# Altium schematic sheet DASH.SchDoc
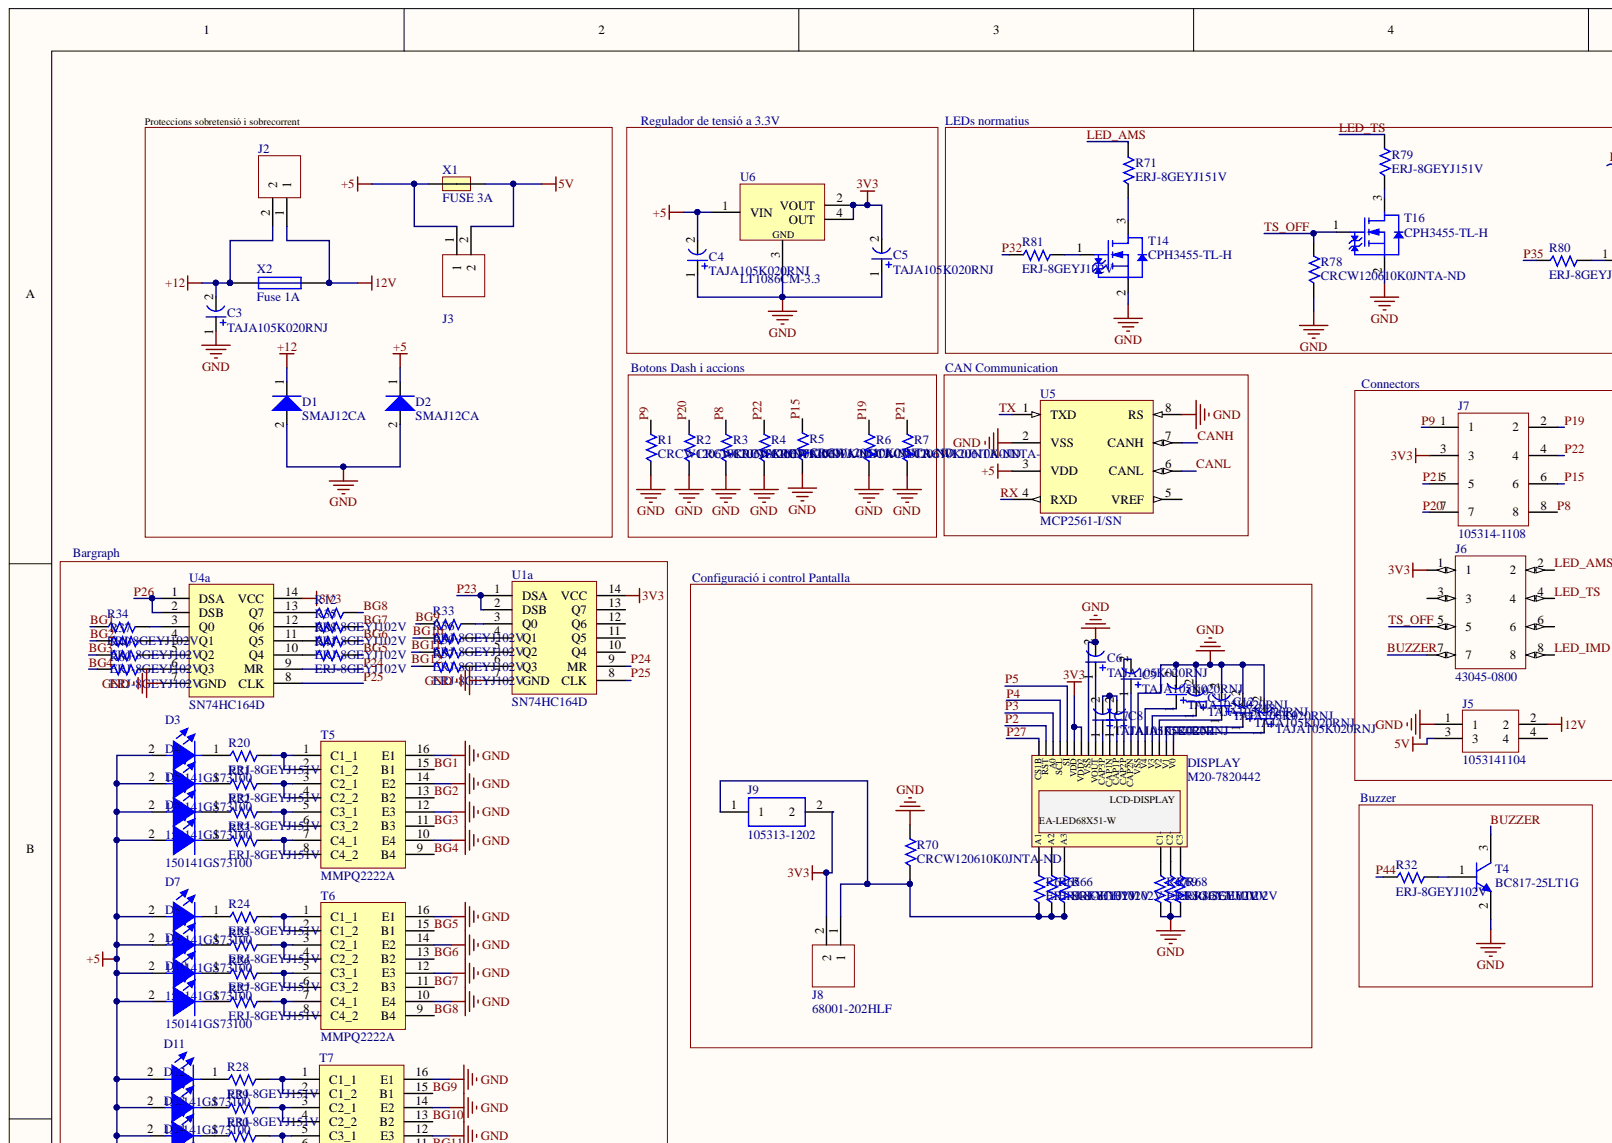
[diagram p0: DASH.SchDoc, top left]
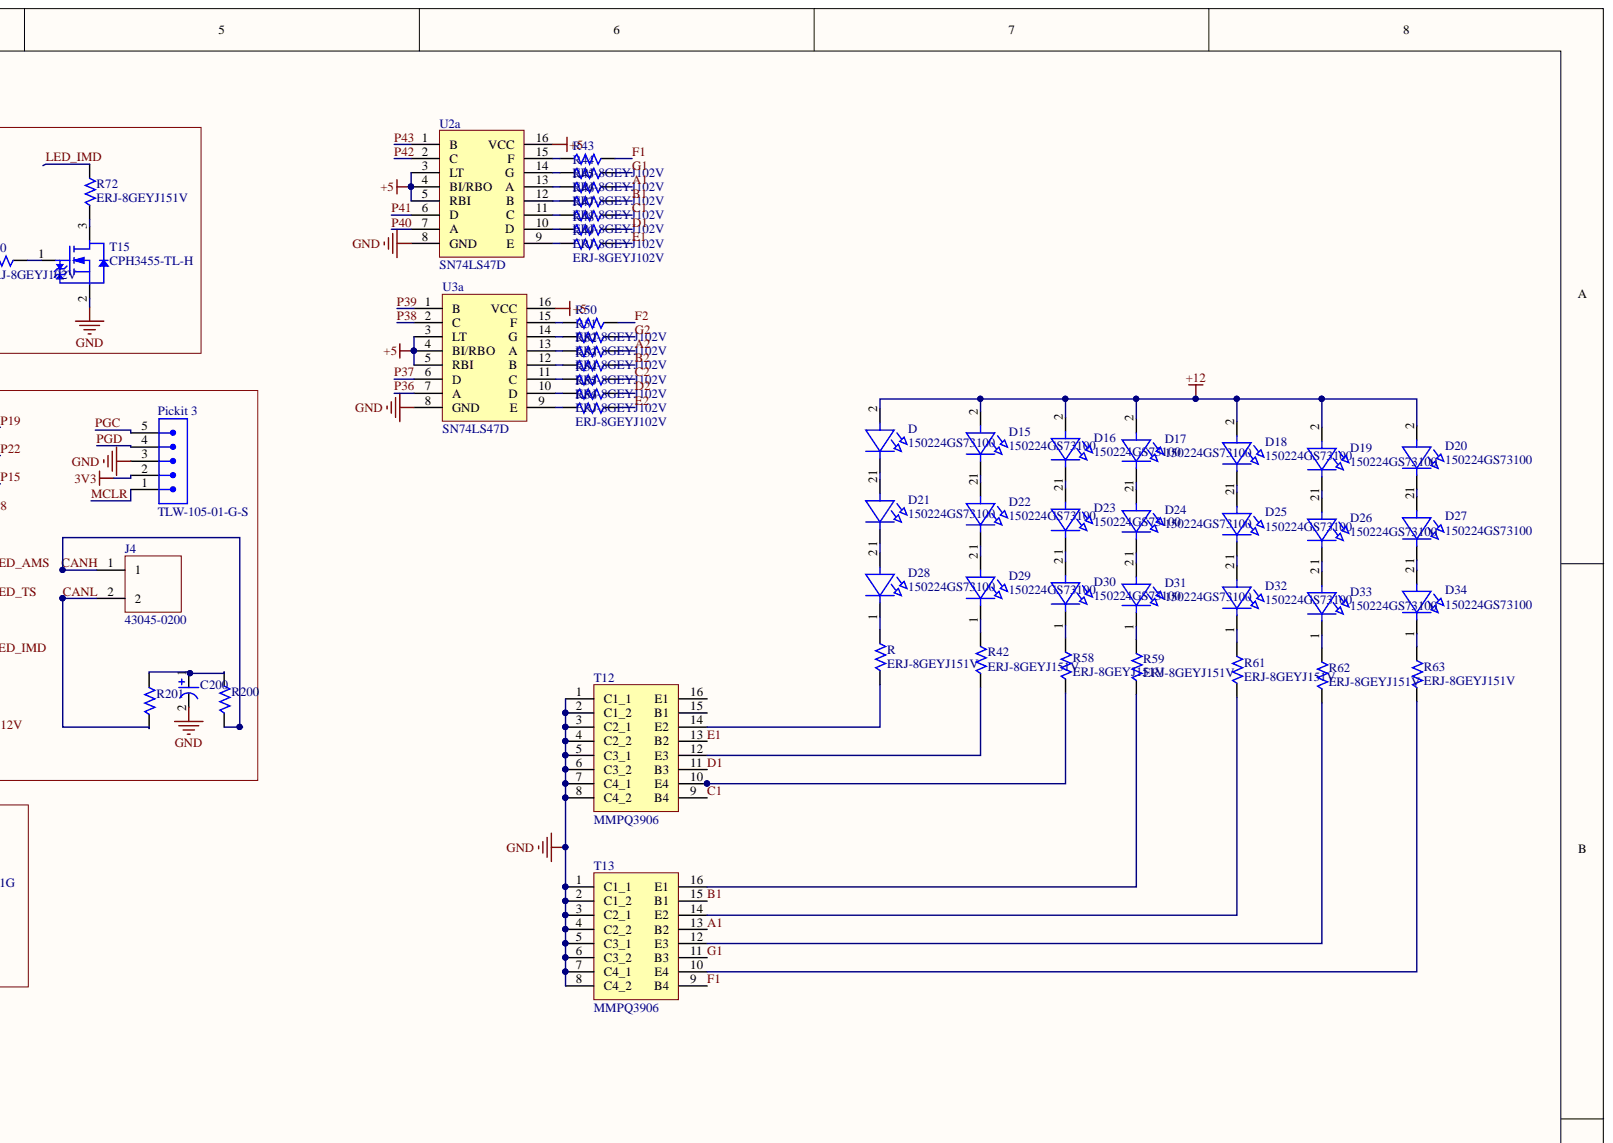
[diagram p1: DASH.SchDoc, top right]
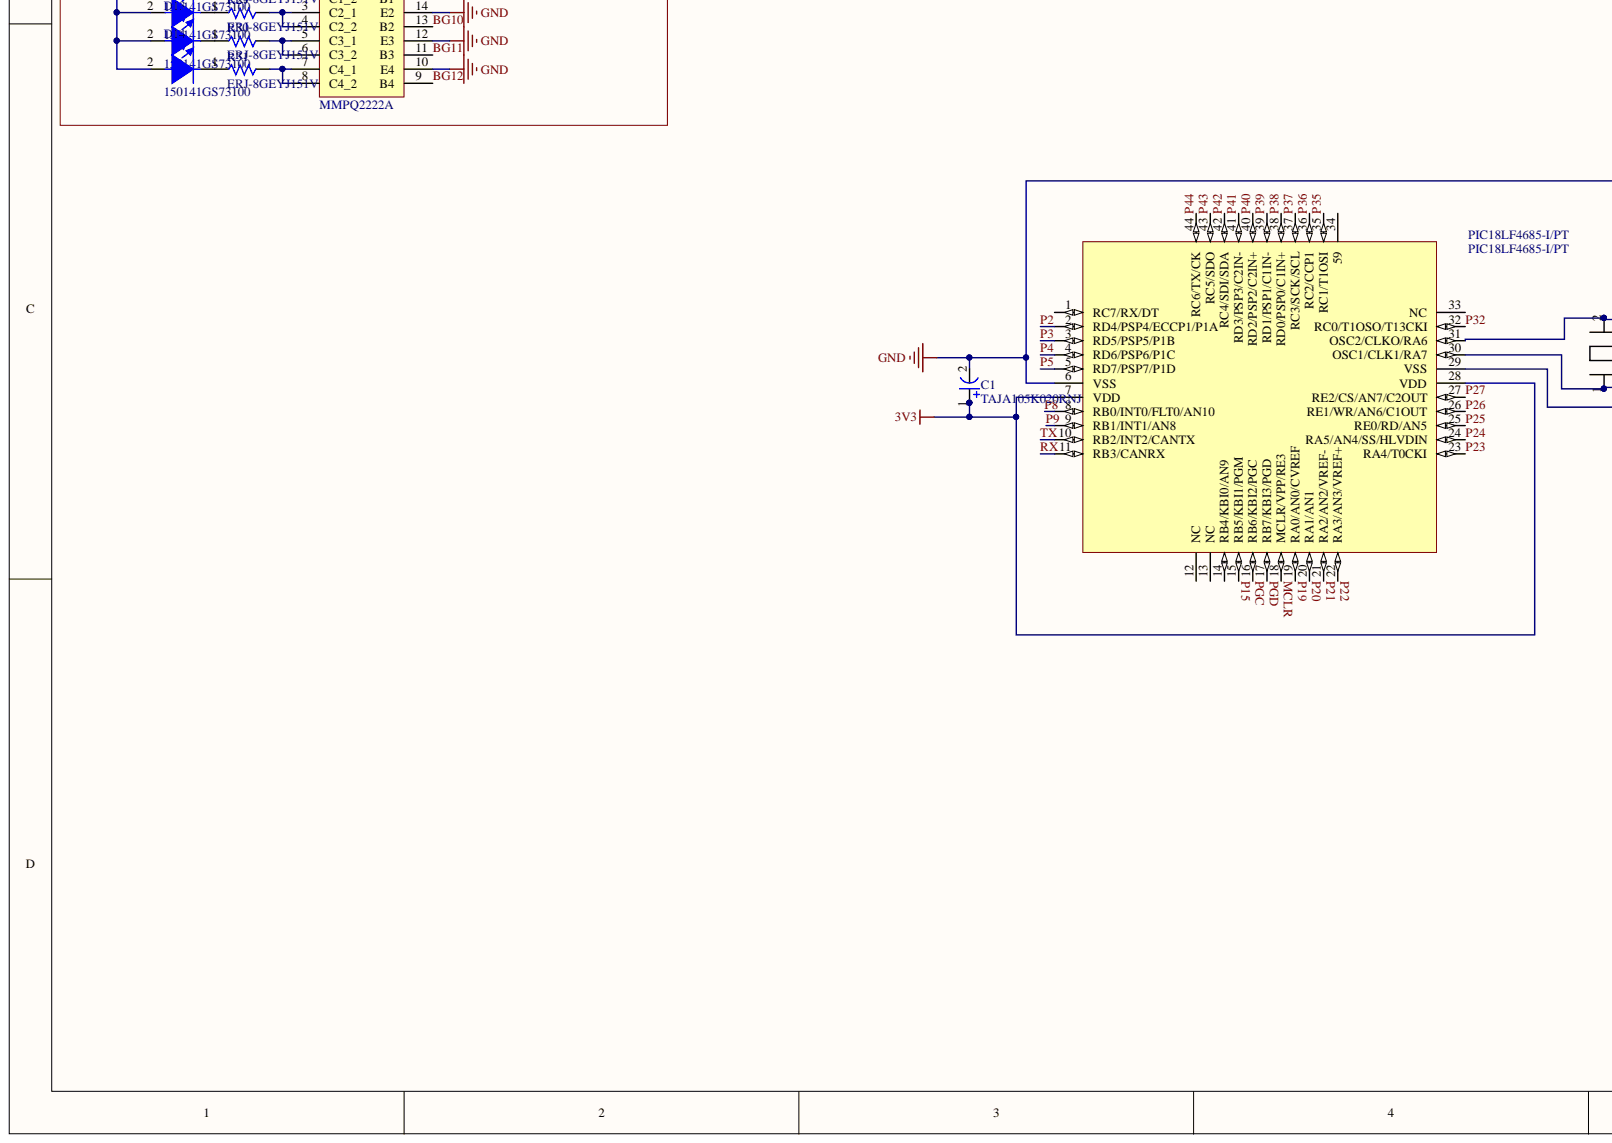
[diagram p2: DASH.SchDoc, bottom left]
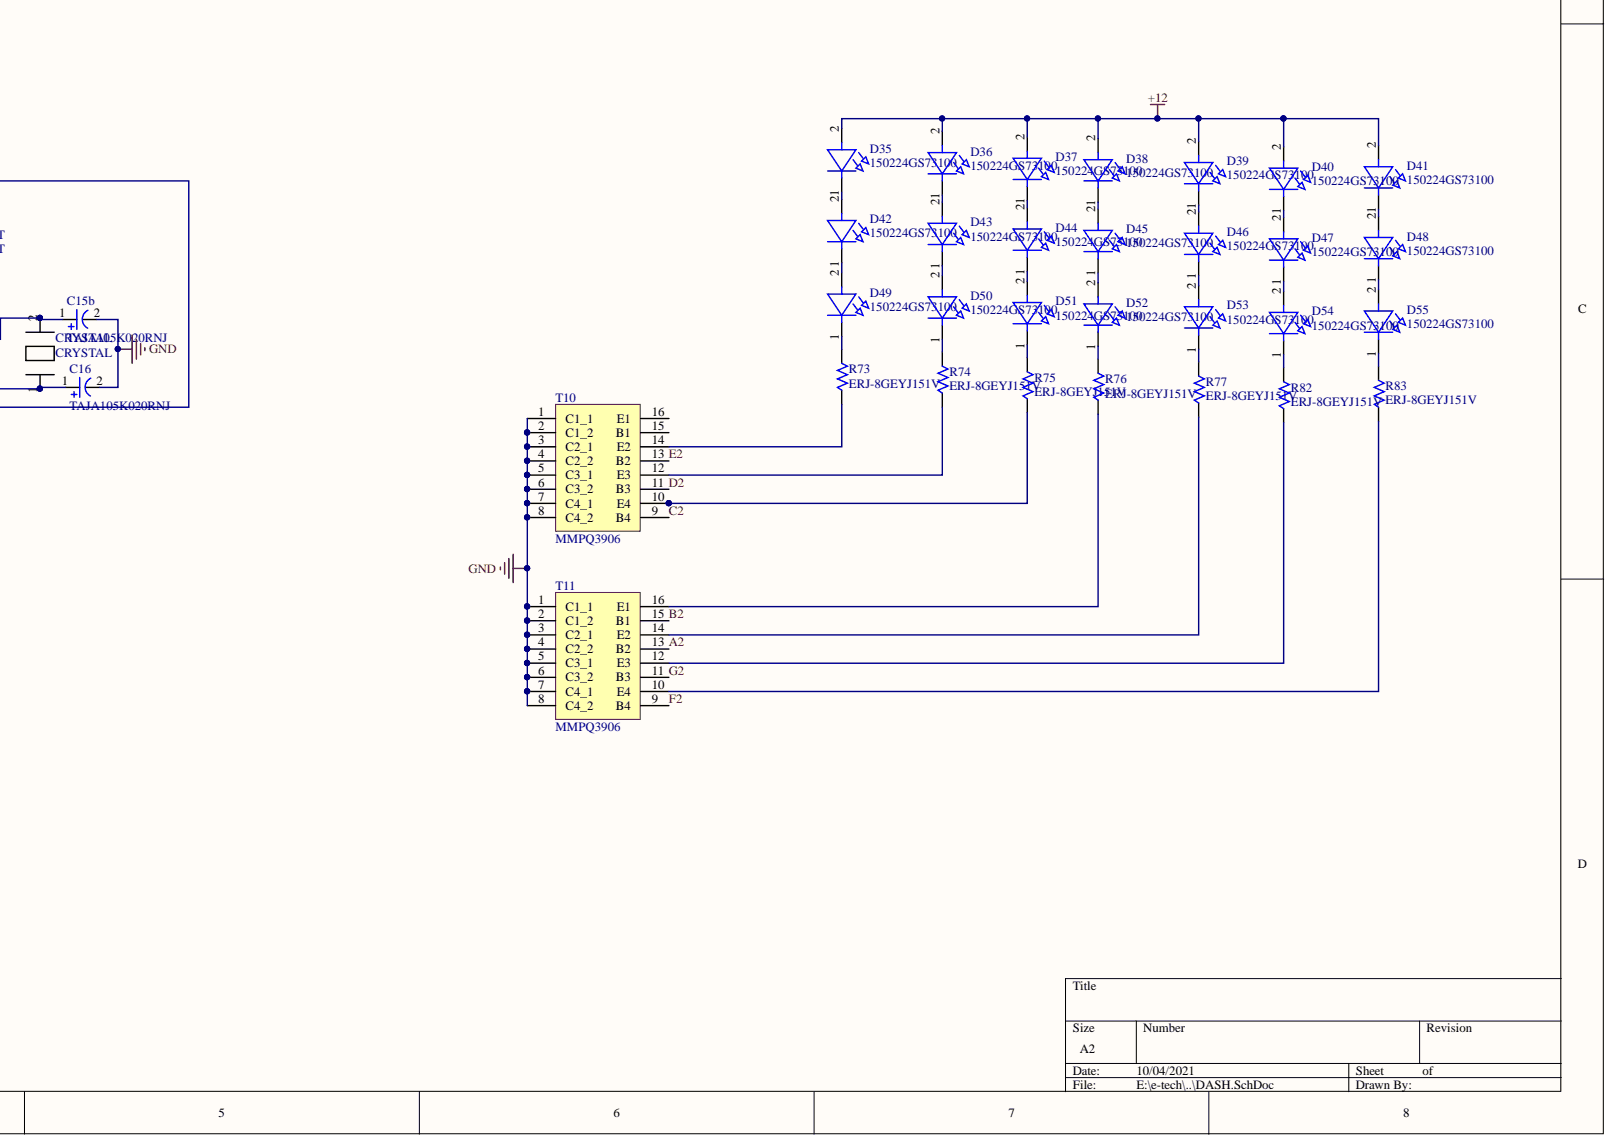
[diagram p3: DASH.SchDoc, bottom right]

## Parts
C1  TAJA105K020RNJ  [Chip2PinSM127P600-8N(condensador)]
C3  TAJA105K020RNJ  [Chip2PinSM127P600-8N(condensador)]
C4  TAJA105K020RNJ  [Chip2PinSM127P600-8N(condensador)]
C5  TAJA105K020RNJ  [Chip2PinSM127P600-8N(condensador)]
C6  TAJA105K020RNJ  [Chip2PinSM127P600-8N(condensador)]
C7  TAJA105K020RNJ  [Chip2PinSM127P600-8N(condensador)]
C8  TAJA105K020RNJ  [Chip2PinSM127P600-8N(condensador)]
C9  TAJA105K020RNJ  [Chip2PinSM127P600-8N(condensador)]
C10  TAJA105K020RNJ  [Chip2PinSM127P600-8N(condensador)]
C11  TAJA105K020RNJ  [Chip2PinSM127P600-8N(condensador)]
C12  TAJA105K020RNJ  [Chip2PinSM127P600-8N(condensador)]
C13  TAJA105K020RNJ  [Chip2PinSM127P600-8N(condensador)]
C14  TAJA105K020RNJ  [Chip2PinSM127P600-8N(condensador)]
C15b  TAJA105K020RNJ  [Chip2PinSM127P600-8N(condensador)]
C16  TAJA105K020RNJ  [Chip2PinSM127P600-8N(condensador)]
C200  TAJA105K020RNJ  [Chip2PinSM127P600-8N(condensador)]
CRYSTAL  CRYSTAL  [CRYSTAL]
D  150224GS73100  [Chip2PinSM127P600-8N]
D1  SMAJ12CA  [Diode]
D2  SMAJ12CA  [Diode]
D3  150141GS73100  [Chip2PinSM127P600-8N(Buzz)]
D4  150141GS73100  [Chip2PinSM127P600-8N(Buzz)]
D5  150141GS73100  [Chip2PinSM127P600-8N(Buzz)]
D6  150141GS73100  [Chip2PinSM127P600-8N(Buzz)]
D7  150141GS73100  [Chip2PinSM127P600-8N(Buzz)]
D8  150141GS73100  [Chip2PinSM127P600-8N(Buzz)]
D9  150141GS73100  [Chip2PinSM127P600-8N(Buzz)]
D10  150141GS73100  [Chip2PinSM127P600-8N(Buzz)]
D11  150141GS73100  [Chip2PinSM127P600-8N(Buzz)]
D12  150141GS73100  [Chip2PinSM127P600-8N(Buzz)]
D13  150141GS73100  [Chip2PinSM127P600-8N(Buzz)]
D14  150141GS73100  [Chip2PinSM127P600-8N(Buzz)]
D15  150224GS73100  [Chip2PinSM127P600-8N]
D16  150224GS73100  [Chip2PinSM127P600-8N]
D17  150224GS73100  [Chip2PinSM127P600-8N]
D18  150224GS73100  [Chip2PinSM127P600-8N]
D19  150224GS73100  [Chip2PinSM127P600-8N]
D20  150224GS73100  [Chip2PinSM127P600-8N]
D21  150224GS73100  [Chip2PinSM127P600-8N]
D22  150224GS73100  [Chip2PinSM127P600-8N]
D23  150224GS73100  [Chip2PinSM127P600-8N]
D24  150224GS73100  [Chip2PinSM127P600-8N]
D25  150224GS73100  [Chip2PinSM127P600-8N]
D26  150224GS73100  [Chip2PinSM127P600-8N]
D27  150224GS73100  [Chip2PinSM127P600-8N]
D28  150224GS73100  [Chip2PinSM127P600-8N]
D29  150224GS73100  [Chip2PinSM127P600-8N]
D30  150224GS73100  [Chip2PinSM127P600-8N]
D31  150224GS73100  [Chip2PinSM127P600-8N]
D32  150224GS73100  [Chip2PinSM127P600-8N]
D33  150224GS73100  [Chip2PinSM127P600-8N]
D34  150224GS73100  [Chip2PinSM127P600-8N]
D35  150224GS73100  [Chip2PinSM127P600-8N]
D36  150224GS73100  [Chip2PinSM127P600-8N]
D37  150224GS73100  [Chip2PinSM127P600-8N]
D38  150224GS73100  [Chip2PinSM127P600-8N]
D39  150224GS73100  [Chip2PinSM127P600-8N]
D40  150224GS73100  [Chip2PinSM127P600-8N]
D41  150224GS73100  [Chip2PinSM127P600-8N]
D42  150224GS73100  [Chip2PinSM127P600-8N]
D43  150224GS73100  [Chip2PinSM127P600-8N]
D44  150224GS73100  [Chip2PinSM127P600-8N]
D45  150224GS73100  [Chip2PinSM127P600-8N]
D46  150224GS73100  [Chip2PinSM127P600-8N]
D47  150224GS73100  [Chip2PinSM127P600-8N]
D48  150224GS73100  [Chip2PinSM127P600-8N]
D49  150224GS73100  [Chip2PinSM127P600-8N]
D50  150224GS73100  [Chip2PinSM127P600-8N]
D51  150224GS73100  [Chip2PinSM127P600-8N]
D52  150224GS73100  [Chip2PinSM127P600-8N]
D53  150224GS73100  [Chip2PinSM127P600-8N]
D54  150224GS73100  [Chip2PinSM127P600-8N]
D55  150224GS73100  [Chip2PinSM127P600-8N]
DISPLAY  M20-7820442  [DISPLAY]
J2  68001-202HLF  [J2]
J3  68001-202HLF  [J3]
J4  43045-0200  [J4]
J5  1053141104  [J5]
J6  43045-0800  [J6]
J7  105314-1108  [J7]
J8  68001-202HLF  [J8]
J9  105313-1202  [J9]
PIC18LF4685-I/PT  PIC18LF4685-I/PT  [TQFP80P1200X1200X120-44N]
Pickit_3  TLW-105-01-G-S  [J1]
R  ERJ-8GEYJ151V  [ERJ-8GEYJ102V]
R1  CRCW120610K0JNTA-ND  [ERJ-8GEYJ102V]
R2  CRCW120610K0JNTA-ND  [ERJ-8GEYJ102V]
R3  CRCW120610K0JNTA-ND  [ERJ-8GEYJ102V]
R4  CRCW120610K0JNTA-ND  [ERJ-8GEYJ102V]
R5  CRCW120610K0JNTA-ND  [ERJ-8GEYJ102V]
R6  CRCW120610K0JNTA-ND  [ERJ-8GEYJ102V]
R7  CRCW120610K0JNTA-ND  [ERJ-8GEYJ102V]
R12  ERJ-8GEYJ102V  [ERJ-8GEYJ102V]
R20  ERJ-8GEYJ151V  [ERJ-8GEYJ102V]
R21  ERJ-8GEYJ151V  [ERJ-8GEYJ102V]
R22  ERJ-8GEYJ151V  [ERJ-8GEYJ102V]
R23  ERJ-8GEYJ151V  [ERJ-8GEYJ102V]
R24  ERJ-8GEYJ151V  [ERJ-8GEYJ102V]
R25  ERJ-8GEYJ151V  [ERJ-8GEYJ102V]
R26  ERJ-8GEYJ151V  [ERJ-8GEYJ102V]
R27  ERJ-8GEYJ151V  [ERJ-8GEYJ102V]
R28  ERJ-8GEYJ151V  [ERJ-8GEYJ102V]
R29  ERJ-8GEYJ151V  [ERJ-8GEYJ102V]
R30  ERJ-8GEYJ151V  [ERJ-8GEYJ102V]
R31  ERJ-8GEYJ151V  [ERJ-8GEYJ102V]
R32  ERJ-8GEYJ102V  [ERJ-8GEYJ102V]
R33  ERJ-8GEYJ102V  [ERJ-8GEYJ102V]
R34  ERJ-8GEYJ102V  [ERJ-8GEYJ102V]
R35  ERJ-8GEYJ102V  [ERJ-8GEYJ102V]
R36  ERJ-8GEYJ102V  [ERJ-8GEYJ102V]
R37  ERJ-8GEYJ102V  [ERJ-8GEYJ102V]
R38  ERJ-8GEYJ102V  [ERJ-8GEYJ102V]
R39  ERJ-8GEYJ102V  [ERJ-8GEYJ102V]
R40  ERJ-8GEYJ102V  [ERJ-8GEYJ102V]
R41  ERJ-8GEYJ102V  [ERJ-8GEYJ102V]
R42  ERJ-8GEYJ151V  [ERJ-8GEYJ102V]
R43  ERJ-8GEYJ102V  [ERJ-8GEYJ102V]
R44  ERJ-8GEYJ102V  [ERJ-8GEYJ102V]
R45  ERJ-8GEYJ102V  [ERJ-8GEYJ102V]
R46  ERJ-8GEYJ102V  [ERJ-8GEYJ102V]
R47  ERJ-8GEYJ102V  [ERJ-8GEYJ102V]
R48  ERJ-8GEYJ102V  [ERJ-8GEYJ102V]
R49  ERJ-8GEYJ102V  [ERJ-8GEYJ102V]
R50  ERJ-8GEYJ102V  [ERJ-8GEYJ102V]
R51  ERJ-8GEYJ102V  [ERJ-8GEYJ102V]
R52  ERJ-8GEYJ102V  [ERJ-8GEYJ102V]
R53  ERJ-8GEYJ102V  [ERJ-8GEYJ102V]
R54  ERJ-8GEYJ102V  [ERJ-8GEYJ102V]
R55  ERJ-8GEYJ102V  [ERJ-8GEYJ102V]
R56  ERJ-8GEYJ102V  [ERJ-8GEYJ102V]
R57  ERJ-8GEYJ102V  [ERJ-8GEYJ102V]
R58  ERJ-8GEYJ151V  [ERJ-8GEYJ102V]
R59  ERJ-8GEYJ151V  [ERJ-8GEYJ102V]
R60  ERJ-8GEYJ102V  [ERJ-8GEYJ102V]
R61  ERJ-8GEYJ151V  [ERJ-8GEYJ102V]
R62  ERJ-8GEYJ151V  [ERJ-8GEYJ102V]
R63  ERJ-8GEYJ151V  [ERJ-8GEYJ102V]
R64  ERJ-8GEYJ102V  [ERJ-8GEYJ102V]
R65  ERJ-8GEYJ102V  [ERJ-8GEYJ102V]
R66  ERJ-8GEYJ102V  [ERJ-8GEYJ102V]
R67  ERJ-8GEYJ102V  [ERJ-8GEYJ102V]
R68  ERJ-8GEYJ102V  [ERJ-8GEYJ102V]
R69  ERJ-8GEYJ102V  [ERJ-8GEYJ102V]
R70  CRCW120610K0JNTA-ND  [ERJ-8GEYJ102V]
R71  ERJ-8GEYJ151V  [ERJ-8GEYJ102V]
R72  ERJ-8GEYJ151V  [ERJ-8GEYJ102V]
R73  ERJ-8GEYJ151V  [ERJ-8GEYJ102V]
R74  ERJ-8GEYJ151V  [ERJ-8GEYJ102V]
R75  ERJ-8GEYJ151V  [ERJ-8GEYJ102V]
R76  ERJ-8GEYJ151V  [ERJ-8GEYJ102V]
R77  ERJ-8GEYJ151V  [ERJ-8GEYJ102V]
R78  CRCW120610K0JNTA-ND  [ERJ-8GEYJ102V]
R79  ERJ-8GEYJ151V  [ERJ-8GEYJ102V]
R80  ERJ-8GEYJ102V  [ERJ-8GEYJ102V]
R81  ERJ-8GEYJ102V  [ERJ-8GEYJ102V]
R82  ERJ-8GEYJ151V  [ERJ-8GEYJ102V]
R83  ERJ-8GEYJ151V  [ERJ-8GEYJ102V]
R200  ERJ-8GEYJ151V  [ERJ-8GEYJ102V]
R201  ERJ-8GEYJ151V  [ERJ-8GEYJ102V]
T4  BC817-25LT1G  [SOT190P240X100-3N]
T5  MMPQ2222A  [SOP127P600-8N(MMPQ2222A)]
T6  MMPQ2222A  [SOP127P600-8N(MMPQ2222A)]
T7  MMPQ2222A  [SOP127P600-8N(MMPQ2222A)]
T10  MMPQ3906  [SOP127P600-8N(MMPQ3906)]
T11  MMPQ3906  [SOP127P600-8N(MMPQ3906)]
T12  MMPQ3906  [SOP127P600-8N(MMPQ3906)]
T13  MMPQ3906  [SOP127P600-8N(MMPQ3906)]
T14  CPH3455-TL-H  [SOT190P280X90-3N]
T15  CPH3455-TL-H  [SOT190P280X90-3N]
T16  CPH3455-TL-H  [SOT190P280X90-3N]
U1a  SN74HC164D  [SOP127P600-8N]
U2a  SN74LS47D  Case/Package: D  [SOP127P620X175-16N]
U3a  SN74LS47D  Case/Package: D  [SOP127P620X175-16N]
U4a  SN74HC164D  [SOP127P600-8N]
U5  MCP2561-I/SN  Interface: CAN  [SOP127P600-8N(MCP2551)]
U6  LT1086CM-3.3  [TO228P970-3N]
X1  FUSE 3A  [Fuse]
X2  Fuse 1A  [Fuse]

## Nets
3V3: C1.1 C5.2 DISPLAY.34(VDD2) DISPLAY.35(VDD) J6.1 J7.3 J8.2 J9.2 PIC18LF4685-I/PT.7(VDD) PIC18LF4685-I/PT.28(VDD) Pickit_3.2 U1a.14(VCC) U4a.14(VCC) U6.2(VOUT) U6.4(OUT)
+5: C4.2 D2.1 D3.2 D4.2 D5.2 D6.2 D7.2 D8.2 D9.2 D10.2 D11.2 D12.2 D13.2 D14.2 J3.1 J3.2 J5.3 U2a.3(LT) U2a.4(BI/RBO) U2a.5(RBI) U2a.16(VCC) U3a.3(LT) U3a.4(BI/RBO) U3a.5(RBI) U3a.16(VCC) U5.3(VDD) U6.1(VIN) X1.1 X1.2
+12: C3.2 D.2 D1.1 D15.2 D16.2 D17.2 D18.2 D19.2 D20.2 D35.2 D36.2 D37.2 D38.2 D39.2 D40.2 D41.2 J2.1 J2.2 J5.2 X2.1 X2.2
GND: C1.2 C3.1 C4.1 C5.1 C6.2 C10.2 C11.2 C12.2 C13.2 C14.2 C15b.2 C16.2 C200.2 D1.2 D2.2 DISPLAY.26(VSS) DISPLAY.33(VSS) J5.1 PIC18LF4685-I/PT.6(VSS) PIC18LF4685-I/PT.29(VSS) Pickit_3.3 R1.1 R2.1 R3.1 R4.1 R5.1 R6.1 R7.1 R67.2 R68.2 R69.2 R70.2 R78.1 T4.2 T5.10(E4) T5.12(E3) T5.14(E2) T5.16(E1) T6.10(E4) T6.12(E3) T6.14(E2) T6.16(E1) T7.10(E4) T7.12(E3) T7.14(E2) T7.16(E1) T10.1(C1_1) T10.2(C1_2) T10.3(C2_1) T10.4(C2_2) T10.5(C3_1) T10.6(C3_2) T10.7(C4_1) T10.8(C4_2) T11.1(C1_1) T11.2(C1_2) T11.3(C2_1) T11.4(C2_2) T11.5(C3_1) T11.6(C3_2) T11.7(C4_1) T11.8(C4_2) T12.1(C1_1) T12.2(C1_2) T12.3(C2_1) T12.4(C2_2) T12.5(C3_1) T12.6(C3_2) T12.7(C4_1) T12.8(C4_2) T13.1(C1_1) T13.2(C1_2) T13.3(C2_1) T13.4(C2_2) T13.5(C3_1) T13.6(C3_2) T13.7(C4_1) T13.8(C4_2) T14.2 T15.2 T16.2 U1a.7(GND) U2a.8(GND) U3a.8(GND) U4a.7(GND) U5.2(VSS) U5.8(RS) U6.3
A1: R45.2 T13.13(B2)
A2: R52.2 T11.13(B2)
B1: R46.2 T13.15(B1)
B2: R53.2 T11.15(B1)
BG1: R34.1 T5.15(B1)
BG2: R37.1 T5.13(B2)
BG3: R40.1 T5.11(B3)
BG4: R60.1 T5.9(B4)
BG5: R41.2 T6.15(B1)
BG6: R38.2 T6.13(B2)
BG7: R35.2 T6.11(B3)
BG8: R12.2 T6.9(B4)
BG9: R33.1 T7.15(B1)
BG10: R36.1 T7.13(B2)
BG11: R39.1 T7.11(B3)
BG12: R57.1 T7.9(B4)
BUZZER: J6.7 T4.3
C1: R47.2 T12.9(B4)
C2: R54.2 T10.9(B4)
CANH: J4.1 R200.1 U5.7(CANH)
CANL: J4.2 R201.1 U5.6(CANL)
D1: R48.2 T12.11(B3)
D2: R55.2 T10.11(B3)
E1: R49.2 T12.13(B2)
E2: R56.2 T10.13(B2)
F1: R43.2 T13.9(B4)
F2: R50.2 T11.9(B4)
G1: R44.2 T13.11(B3)
G2: R51.2 T11.11(B3)
LED_AMS: J6.2 R71.2
LED_IMD: J6.8 R72.2
LED_TS: J6.4 R79.2
MCLR: PIC18LF4685-I/PT.18(MCLR/VPP/RE3) Pickit_3.1
P2: DISPLAY.39(RST) PIC18LF4685-I/PT.2(RD4/PSP4/ECCP1/P1A)
P3: DISPLAY.38(A0) PIC18LF4685-I/PT.3(RD5/PSP5/P1B)
P4: DISPLAY.37(SCL) PIC18LF4685-I/PT.4(RD6/PSP6/P1C)
P5: DISPLAY.36(SI) PIC18LF4685-I/PT.5(RD7/PSP7/P1D)
P8: J7.8 PIC18LF4685-I/PT.8(RB0/INT0/FLT0/AN10) R3.2
P9: J7.1 PIC18LF4685-I/PT.9(RB1/INT1/AN8) R1.2
P15: J7.6 PIC18LF4685-I/PT.15(RB5/KBI1/PGM) R5.2
P19: J7.2 PIC18LF4685-I/PT.19(RA0/AN0/CVREF) R6.2
P20: J7.7 PIC18LF4685-I/PT.20(RA1/AN1) R2.2
P21: J7.5 PIC18LF4685-I/PT.21(RA2/AN2/VREF-) R7.2
P22: J7.4 PIC18LF4685-I/PT.22(RA3/AN3/VREF+) R4.2
P23: PIC18LF4685-I/PT.23(RA4/T0CKI) U1a.1(DSA) U1a.2(DSB)
P24: PIC18LF4685-I/PT.24(RA5/AN4/SS/HLVDIN) U1a.9(MR) U4a.9(MR)
P25: PIC18LF4685-I/PT.25(RE0/RD/AN5) U1a.8(CLK) U4a.8(CLK)
P26: PIC18LF4685-I/PT.26(RE1/WR/AN6/C1OUT) U4a.1(DSA) U4a.2(DSB)
P27: DISPLAY.40(CS1B) PIC18LF4685-I/PT.27(RE2/CS/AN7/C2OUT)
P32: PIC18LF4685-I/PT.32(RC0/T1OSO/T13CKI) R81.1
P35: PIC18LF4685-I/PT.35(RC1/T1OSI) R80.1
P36: PIC18LF4685-I/PT.36(RC2/CCP1) U3a.7(A)
P37: PIC18LF4685-I/PT.37(RC3/SCK/SCL) U3a.6(D)
P38: PIC18LF4685-I/PT.38(RD0/PSP0/C1IN+) U3a.2(C)
P39: PIC18LF4685-I/PT.39(RD1/PSP1/C1IN-) U3a.1(B)
P40: PIC18LF4685-I/PT.40(RD2/PSP2/C2IN+) U2a.7(A)
P41: PIC18LF4685-I/PT.41(RD3/PSP3/C2IN-) U2a.6(D)
P42: PIC18LF4685-I/PT.42(RC4/SDI/SDA) U2a.2(C)
P43: PIC18LF4685-I/PT.43(RC5/SDO) U2a.1(B)
P44: PIC18LF4685-I/PT.44(RC6/TX/CK) R32.1
PGC: PIC18LF4685-I/PT.16(RB6/KBI2/PGC) Pickit_3.5
PGD: PIC18LF4685-I/PT.17(RB7/KBI3/PGD) Pickit_3.4
RX: PIC18LF4685-I/PT.11(RB3/CANRX) U5.4(RXD)
TS_OFF: J6.5 R78.2 T16.1
TX: PIC18LF4685-I/PT.10(RB2/INT2/CANTX) U5.1(TXD)
NetC6_1: C6.1 DISPLAY.32(VOUT)
NetC7_1: C7.1 DISPLAY.31(CAP3P)
NetC7_2: C7.2 C8.2 DISPLAY.30(CAP1N)
NetC8_1: C8.1 DISPLAY.29(CAP1P)
NetC9_1: C9.1 DISPLAY.27(CAP2N)
NetC9_2: C9.2 DISPLAY.28(CAP2P)
NetC10_1: C10.1 DISPLAY.25(V4)
NetC11_1: C11.1 DISPLAY.24(V3)
NetC12_1: C12.1 DISPLAY.23(V2)
NetC13_1: C13.1 DISPLAY.22(V1)
NetC14_1: C14.1 DISPLAY.21(V0)
NetC15b_1: C15b.1 CRYSTAL.2 PIC18LF4685-I/PT.31(OSC2/CLKO/RA6)
NetC16_1: C16.1 CRYSTAL.1 PIC18LF4685-I/PT.30(OSC1/CLK1/RA7)
NetC200_1: C200.1 R200.2 R201.2
NetD3_1: D3.1 R20.1
NetD4_1: D4.1 R21.1
NetD5_1: D5.1 R22.1
NetD6_1: D6.1 R23.1
NetD7_1: D7.1 R24.1
NetD8_1: D8.1 R25.1
NetD9_1: D9.1 R26.1
NetD10_1: D10.1 R27.1
NetD11_1: D11.1 R28.1
NetD12_1: D12.1 R29.1
NetD13_1: D13.1 R30.1
NetD14_1: D14.1 R31.1
NetD15_1: D15.1 D22.2
NetD16_1: D16.1 D23.2
NetD17_1: D17.1 D24.2
NetD18_1: D18.1 D25.2
NetD19_1: D19.1 D26.2
NetD20_1: D20.1 D27.2
NetD21_1: D21.1 D28.2
NetD21_2: D.1 D21.2
NetD22_1: D22.1 D29.2
NetD23_1: D23.1 D30.2
NetD24_1: D24.1 D31.2
NetD25_1: D25.1 D32.2
NetD26_1: D26.1 D33.2
NetD27_1: D27.1 D34.2
NetD28_1: D28.1 R.2
NetD29_1: D29.1 R42.2
NetD30_1: D30.1 R58.2
NetD31_1: D31.1 R59.2
NetD32_1: D32.1 R61.2
NetD33_1: D33.1 R62.2
NetD34_1: D34.1 R63.2
NetD35_1: D35.1 D42.2
NetD36_1: D36.1 D43.2
NetD37_1: D37.1 D44.2
NetD38_1: D38.1 D45.2
NetD39_1: D39.1 D46.2
NetD40_1: D40.1 D47.2
NetD41_1: D41.1 D48.2
NetD42_1: D42.1 D49.2
NetD43_1: D43.1 D50.2
NetD44_1: D44.1 D51.2
NetD45_1: D45.1 D52.2
NetD46_1: D46.1 D53.2
NetD47_1: D47.1 D54.2
NetD48_1: D48.1 D55.2
NetD49_1: D49.1 R73.2
NetD50_1: D50.1 R74.2
NetD51_1: D51.1 R75.2
NetD52_1: D52.1 R76.2
NetD53_1: D53.1 R77.2
NetD54_1: D54.1 R82.2
NetD55_1: D55.1 R83.2
NetDISPLAY_41: DISPLAY.41(A1) R64.2
NetDISPLAY_42: DISPLAY.42(A2) R65.2
NetDISPLAY_43: DISPLAY.43(A3) R66.2
NetDISPLAY_44: DISPLAY.44(C3-) R68.1
NetDISPLAY_45: DISPLAY.45(C2-) R69.1
NetDISPLAY_46: DISPLAY.46(C1-) R67.1
NetJ8_1: J8.1 J9.1 R64.1 R65.1 R66.1 R70.1
NetR12_1: R12.1 U4a.13(Q7)
NetR20_2: R20.2 T5.1(C1_1) T5.2(C1_2)
NetR21_2: R21.2 T5.3(C2_1) T5.4(C2_2)
NetR22_2: R22.2 T5.5(C3_1) T5.6(C3_2)
NetR23_2: R23.2 T5.7(C4_1) T5.8(C4_2)
NetR24_2: R24.2 T6.1(C1_1) T6.2(C1_2)
NetR25_2: R25.2 T6.3(C2_1) T6.4(C2_2)
NetR26_2: R26.2 T6.5(C3_1) T6.6(C3_2)
NetR27_2: R27.2 T6.7(C4_1) T6.8(C4_2)
NetR28_2: R28.2 T7.1(C1_1) T7.2(C1_2)
NetR29_2: R29.2 T7.3(C2_1) T7.4(C2_2)
NetR30_2: R30.2 T7.5(C3_1) T7.6(C3_2)
NetR31_2: R31.2 T7.7(C4_1) T7.8(C4_2)
NetR32_2: R32.2 T4.1
NetR33_2: R33.2 U1a.3(Q0)
NetR34_2: R34.2 U4a.3(Q0)
NetR35_1: R35.1 U4a.12(Q6)
NetR36_2: R36.2 U1a.4(Q1)
NetR37_2: R37.2 U4a.4(Q1)
NetR38_1: R38.1 U4a.11(Q5)
NetR39_2: R39.2 U1a.5(Q2)
NetR40_2: R40.2 U4a.5(Q2)
NetR41_1: R41.1 U4a.10(Q4)
NetR42_1: R42.1 T12.12(E3)
NetR43_1: R43.1 U2a.15(F)
NetR44_1: R44.1 U2a.14(G)
NetR45_1: R45.1 U2a.13(A)
NetR46_1: R46.1 U2a.12(B)
NetR47_1: R47.1 U2a.11(C)
NetR48_1: R48.1 U2a.10(D)
NetR49_1: R49.1 U2a.9(E)
NetR50_1: R50.1 U3a.15(F)
NetR51_1: R51.1 U3a.14(G)
NetR52_1: R52.1 U3a.13(A)
NetR53_1: R53.1 U3a.12(B)
NetR54_1: R54.1 U3a.11(C)
NetR55_1: R55.1 U3a.10(D)
NetR56_1: R56.1 U3a.9(E)
NetR57_2: R57.2 U1a.6(Q3)
NetR58_1: R58.1 T12.10(E4)
NetR59_1: R59.1 T13.16(E1)
NetR60_2: R60.2 U4a.6(Q3)
NetR61_1: R61.1 T13.14(E2)
NetR62_1: R62.1 T13.12(E3)
NetR63_1: R63.1 T13.10(E4)
NetR71_1: R71.1 T14.3
NetR72_1: R72.1 T15.3
NetR73_1: R73.1 T10.14(E2)
NetR74_1: R74.1 T10.12(E3)
NetR75_1: R75.1 T10.10(E4)
NetR76_1: R76.1 T11.16(E1)
NetR77_1: R77.1 T11.14(E2)
NetR79_1: R79.1 T16.3
NetR80_2: R80.2 T15.1
NetR81_2: R81.2 T14.1
NetR82_1: R82.1 T11.12(E3)
NetR83_1: R83.1 T11.10(E4)
NetR_1: R.1 T12.14(E2)

Not connected: J5 4; J6 3 6; PIC18LF4685-I/PT 1(RC7/RX/DT) 12(NC) 13(NC) 14(RB4/KBI0/AN9) 33(NC) 34; T10 15(B1) 16(E1); T12 15(B1) 16(E1); U1a 10(Q4) 11(Q5) 12(Q6) 13(Q7); U5 5(VREF)
